# Altium schematic sheet Main Board-main_board_radio-v2.0.0.SchDoc("Main Board-main_board_radio-v2.0.0")
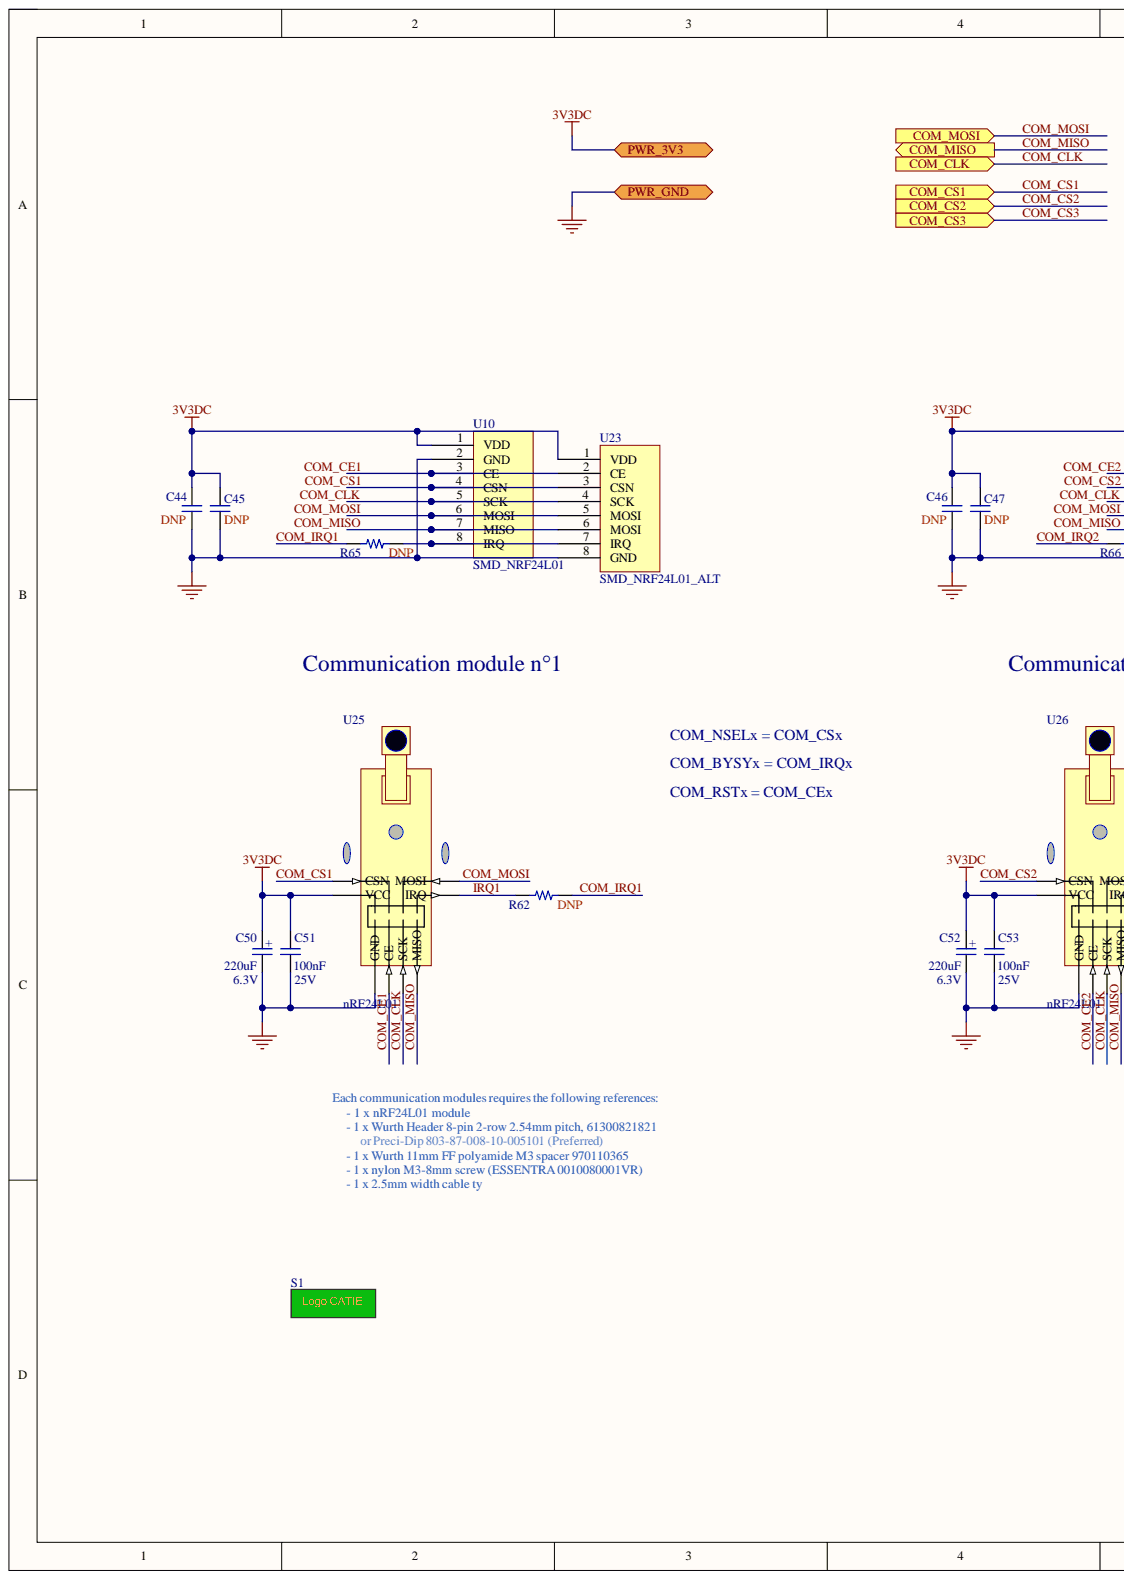
[diagram p0: Main Board-main_board_radio-v2.0.0.SchDoc, left]
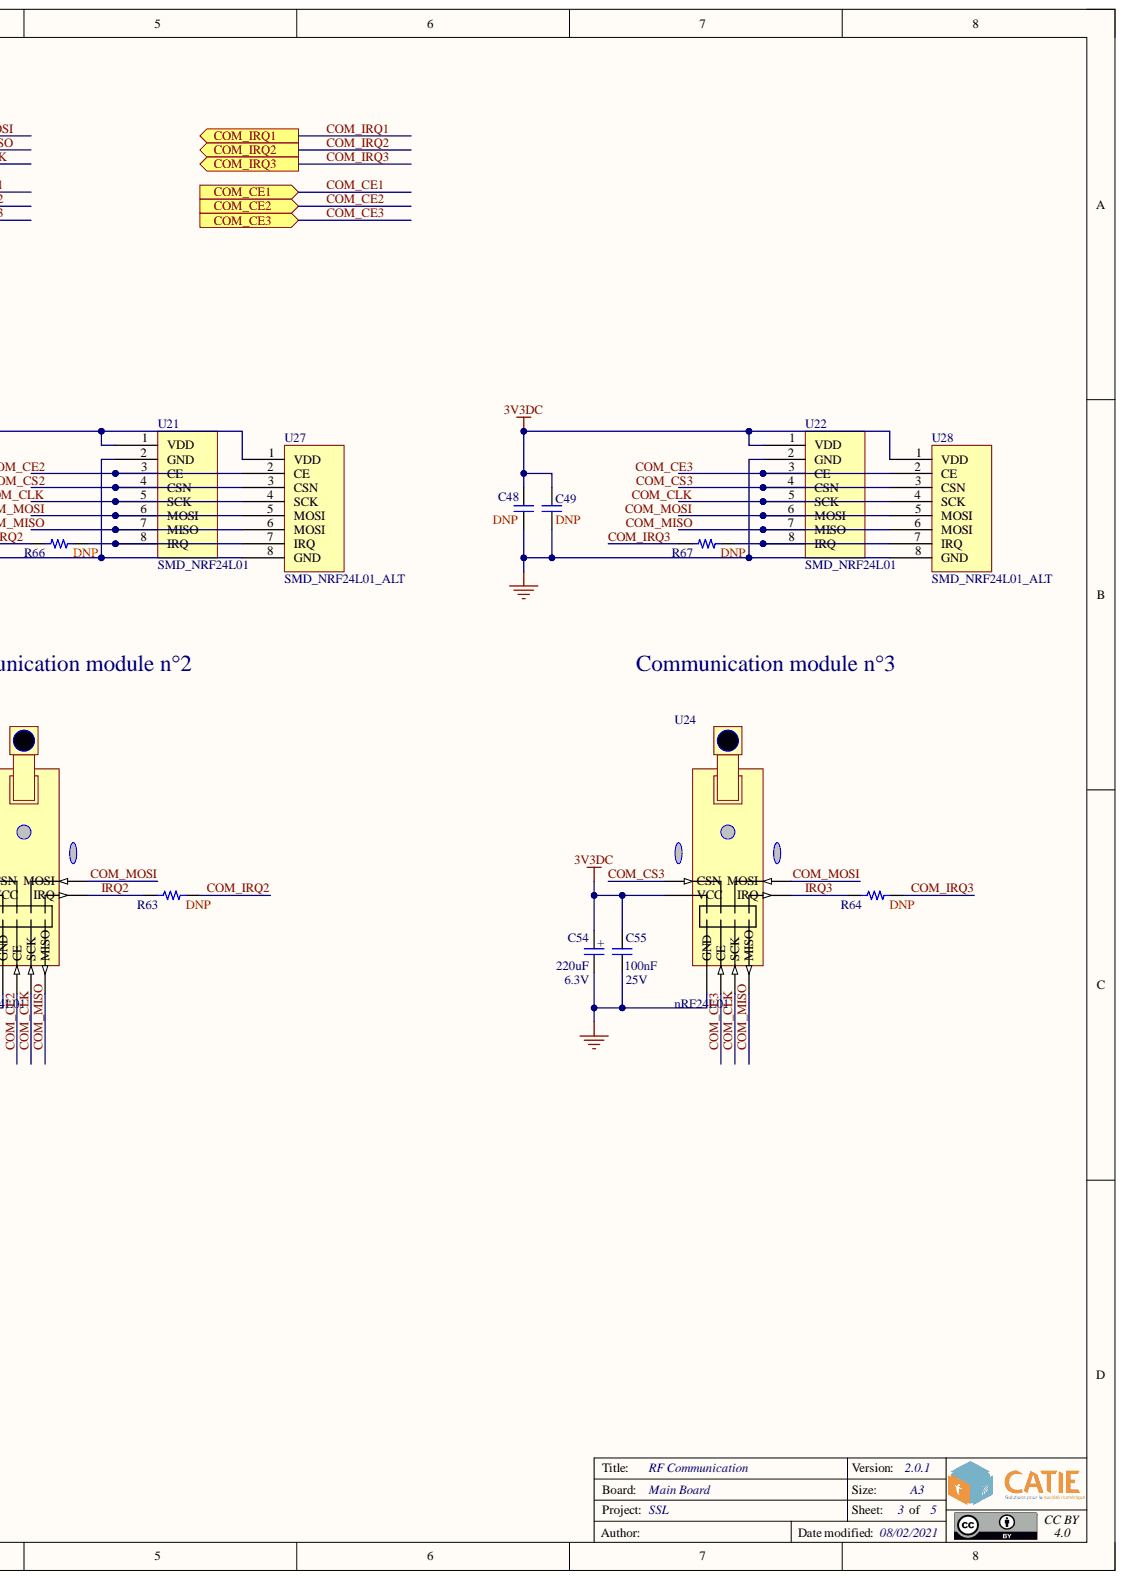
[diagram p1: Main Board-main_board_radio-v2.0.0.SchDoc, right]

## Parts
C44  Mount: DNP  [CAP_CER_0603]  (hidden comment: CAP_CER_0603)
C45  Mount: DNP  [CAP_CER_0603]  (hidden comment: CAP_CER_0603)
C46  Mount: DNP  [CAP_CER_0603]  (hidden comment: CAP_CER_0603)
C47  Mount: DNP  [CAP_CER_0603]  (hidden comment: CAP_CER_0603)
C48  Mount: DNP  [CAP_CER_0603]  (hidden comment: CAP_CER_0603)
C49  Mount: DNP  [CAP_CER_0603]  (hidden comment: CAP_CER_0603)
C50  value 220uF  Rating: 6.3V  [CAP_ELECTRO_TH_D5xH7]  (hidden comment: CAP_ELECTRO_TH_D5xH7)
C51  value 100nF  Rating: 25V  [CAP_CER_0603]  (hidden comment: CAP_CER_0603)
C52  value 220uF  Rating: 6.3V  [CAP_ELECTRO_TH_D5xH7]  (hidden comment: CAP_ELECTRO_TH_D5xH7)
C53  value 100nF  Rating: 25V  [CAP_CER_0603]  (hidden comment: CAP_CER_0603)
C54  value 220uF  Rating: 6.3V  [CAP_ELECTRO_TH_D5xH7]  (hidden comment: CAP_ELECTRO_TH_D5xH7)
C55  value 100nF  Rating: 25V  [CAP_CER_0603]  (hidden comment: CAP_CER_0603)
R62  Mount: DNP  [RES_0603]  (hidden comment: RES_0603)
R63  Mount: DNP  [RES_0603]  (hidden comment: RES_0603)
R64  Mount: DNP  [RES_0603]  (hidden comment: RES_0603)
R65  Mount: DNP  [RES_0603]  (hidden comment: RES_0603)
R66  Mount: DNP  [RES_0603]  (hidden comment: RES_0603)
R67  Mount: DNP  [RES_0603]  (hidden comment: RES_0603)
S1  Reference: CATIE  Type: Logo  [LOGO_CATIE]  (hidden comment: LOGO_CATIE)  (no BOM)
U10  SMD_NRF24L01  [E01-ML01S]
U21  SMD_NRF24L01  [E01-ML01S]
U22  SMD_NRF24L01  [E01-ML01S]
U23  SMD_NRF24L01_ALT  [E01-ML01S]
U24  Reference: nRF24L01  [MODULE_NRF24L01]  (hidden comment: MODULE_NRF24L01)
U25  Reference: nRF24L01  [MODULE_NRF24L01]  (hidden comment: MODULE_NRF24L01)
U26  Reference: nRF24L01  [MODULE_NRF24L01]  (hidden comment: MODULE_NRF24L01)
U27  SMD_NRF24L01_ALT  [E01-ML01S]
U28  SMD_NRF24L01_ALT  [E01-ML01S]

## Ports
COM_CE1, COM_CE2, COM_CE3, COM_CLK, COM_CS1, COM_CS2, COM_CS3, COM_IRQ1, COM_IRQ2, COM_IRQ3, COM_MISO, COM_MOSI, PWR_3V3, PWR_GND

## Nets
3V3DC: C44.1 C45.1 C46.1 C47.1 C48.1 C49.1 C50.2 C51.1 C52.2 C53.1 C54.2 C55.1 U10.1(VDD) U21.1(VDD) U22.1(VDD) U23.1(VDD) U24.2(VCC) U25.2(VCC) U26.2(VCC) U27.1(VDD) U28.1(VDD) ; port PWR_3V3
COM_CE1: U10.3(CE) U23.2(CE) U25.3(CE) ; port COM_CE1
COM_CE2: U21.3(CE) U26.3(CE) U27.2(CE) ; port COM_CE2
COM_CE3: U22.3(CE) U24.3(CE) U28.2(CE) ; port COM_CE3
COM_CLK: U10.5(SCK) U21.5(SCK) U22.5(SCK) U23.4(SCK) U24.5(SCK) U25.5(SCK) U26.5(SCK) U27.4(SCK) U28.4(SCK) ; port COM_CLK
COM_CS1: U10.4(CSN) U23.3(CSN) U25.4(CSN) ; port COM_CS1
COM_CS2: U21.4(CSN) U26.4(CSN) U27.3(CSN) ; port COM_CS2
COM_CS3: U22.4(CSN) U24.4(CSN) U28.3(CSN) ; port COM_CS3
COM_IRQ1: R62.2 R65.1 ; port COM_IRQ1
COM_IRQ2: R63.2 R66.1 ; port COM_IRQ2
COM_IRQ3: R64.2 R67.1 ; port COM_IRQ3
COM_MISO: U10.7(MISO) U21.7(MISO) U22.7(MISO) U23.6(MOSI) U24.7(MISO) U25.7(MISO) U26.7(MISO) U27.6(MOSI) U28.6(MOSI) ; port COM_MISO
COM_MOSI: U10.6(MOSI) U21.6(MOSI) U22.6(MOSI) U23.5(MOSI) U24.6(MOSI) U25.6(MOSI) U26.6(MOSI) U27.5(MOSI) U28.5(MOSI) ; port COM_MOSI
GND: C44.2 C45.2 C46.2 C47.2 C48.2 C49.2 C50.1 C51.2 C52.1 C53.2 C54.1 C55.2 U10.2(GND) U21.2(GND) U22.2(GND) U23.8(GND) U24.1(GND) U25.1(GND) U26.1(GND) U27.8(GND) U28.8(GND) ; port PWR_GND
IRQ1: R62.1 U25.8(IRQ)
IRQ2: R63.1 U26.8(IRQ)
IRQ3: R64.1 U24.8(IRQ)
NetR65_2: R65.2 U10.8(IRQ) U23.7(IRQ)
NetR66_2: R66.2 U21.8(IRQ) U27.7(IRQ)
NetR67_2: R67.2 U22.8(IRQ) U28.7(IRQ)

## Library data of the parts
C44 C46 C48 = CAP_CER_0603: Capacitor ceramics SMD 0603; Manufacturer: Samsung; Ceram: X5R; Rating: 10V; Reference: CL10A475KP8NNNC; Tol: 10%; Value: 4.7uF; Case: 0603; Component Kind: Standard; Library Name: Schematic_Components.SchLib; Technology: CMS; Type: Capacitor
C45 C47 C49 = CAP_CER_0603: Capacitor ceramics SMD 0603; Manufacturer: Samsung; Ceram: X7R; Rating: 25V; Reference: CL10A104KA8NNNC; Tol: 10%; Value: 100nF; Case: 0603; Component Kind: Standard; Library Name: Schematic_Components.SchLib; Technology: CMS; Type: Capacitor
C50 C52 C54 = CAP_ELECTRO_TH_D5xH7: Electrolytic capacitor through-hole D = 5mm - H = 7mm; Manufacturer: KEMET; Reference: A750BG227M0JAAE020; Tol: 20%; Case: D=5 H =7; Component Kind: Standard; Mount: Mnt; Technology: TRAD; Type: Capacitor
C51 C53 C55 = CAP_CER_0603: Capacitor ceramics SMD 0603; Manufacturer: Samsung; Ceram: X7R; Reference: CL10A104KA8NNNC; Tol: 10%; Case: 0603; Component Kind: Standard; Library Name: Schematic_Components.SchLib; Mount: Mnt; Technology: CMS; Type: Capacitor
R62-R67 = RES_0603: Resistor SMD 0603; Manufacturer: Yageo; Rating: 1/10W; Reference: RC0603JR-070RL; Tol: NA; Value: 0R; Case: 0603; Component Kind: Standard; Technology: CMS; Type: Resistor
S1 = LOGO_CATIE: Logo CATIE Full Silkscreen; Mount: DNP; Library Name: Schematic_Components.SchLib
U10 U21 U22 = SMD_NRF24L01: Mount: DNP; Component Kind: Standard; Library Name: MainBoard_Schlib.SchLib
U23 U27 U28 = SMD_NRF24L01_ALT: Mount: DNP; Component Kind: Standard; Library Name: MainBoard_Schlib.SchLib
U24-U26 = MODULE_NRF24L01: Manufacturer: Nordic Semi; Mount: DNP; Value: 3.6V; Component Kind: Standard; Description: MODULE_NRF24L01; Library Name: MainBoard_Schlib.SchLib; Technology: TRAD; Type: Module
